# Altium schematic sheet beta.SchDoc
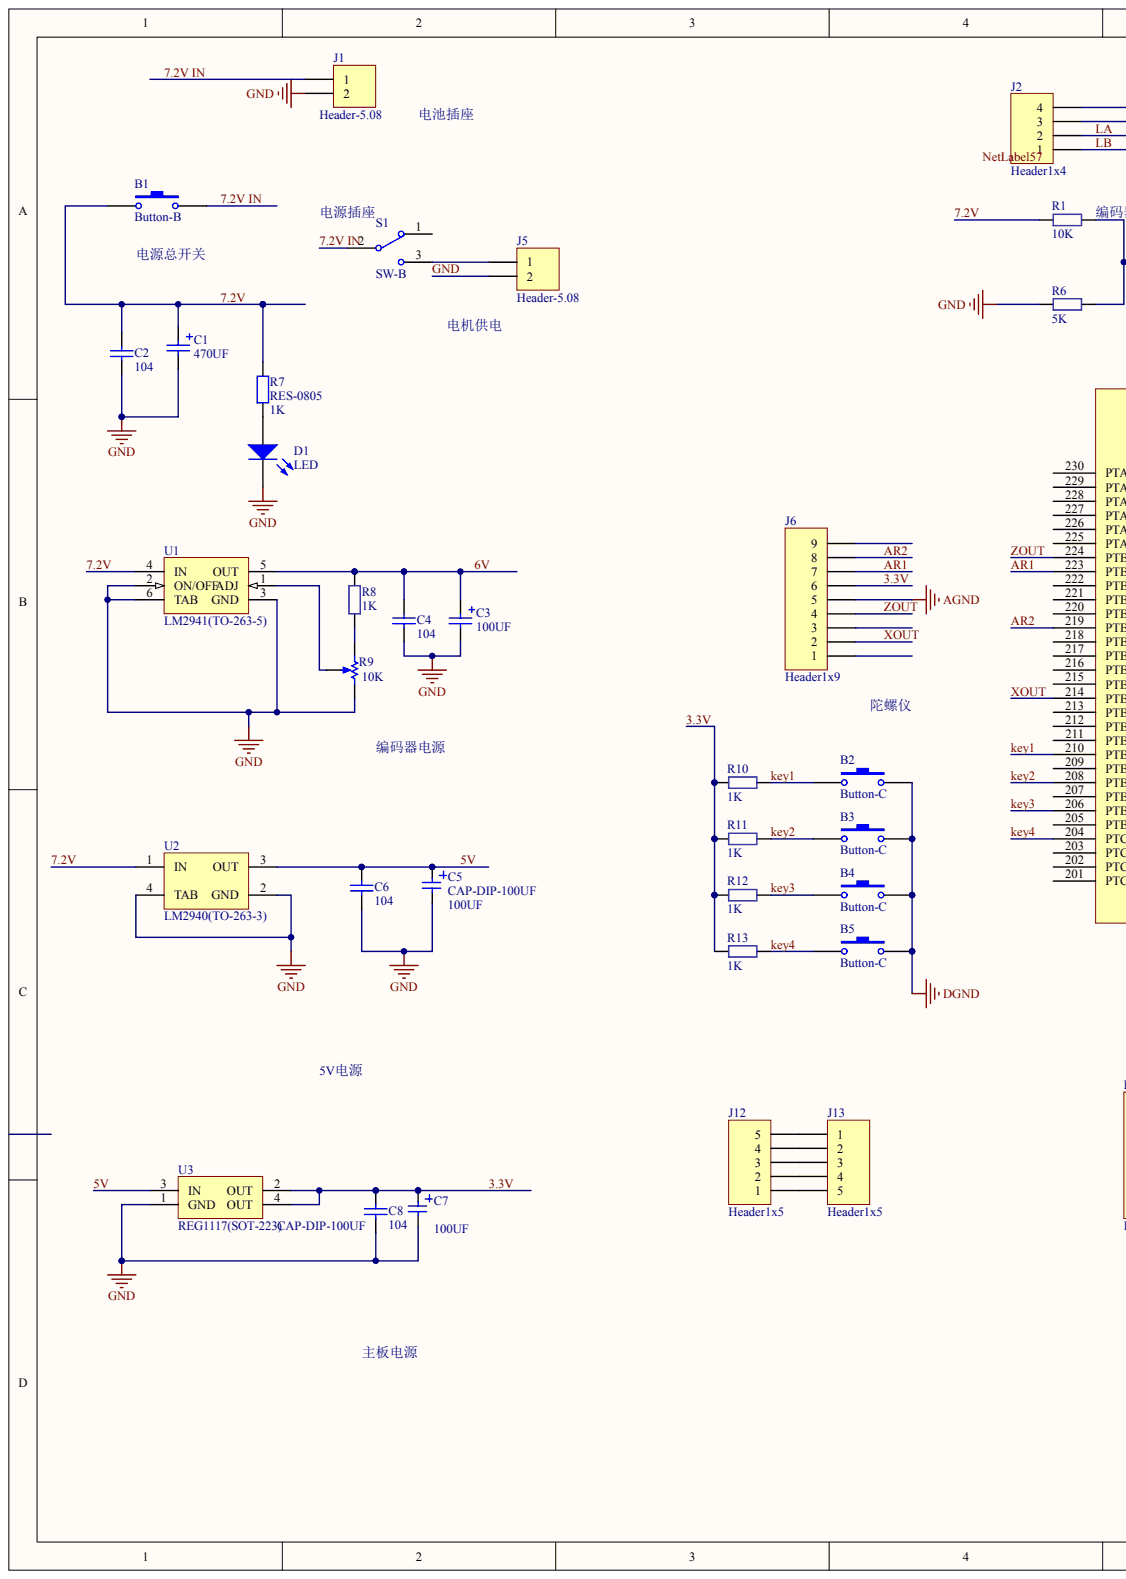
[diagram p0: beta.SchDoc, left]
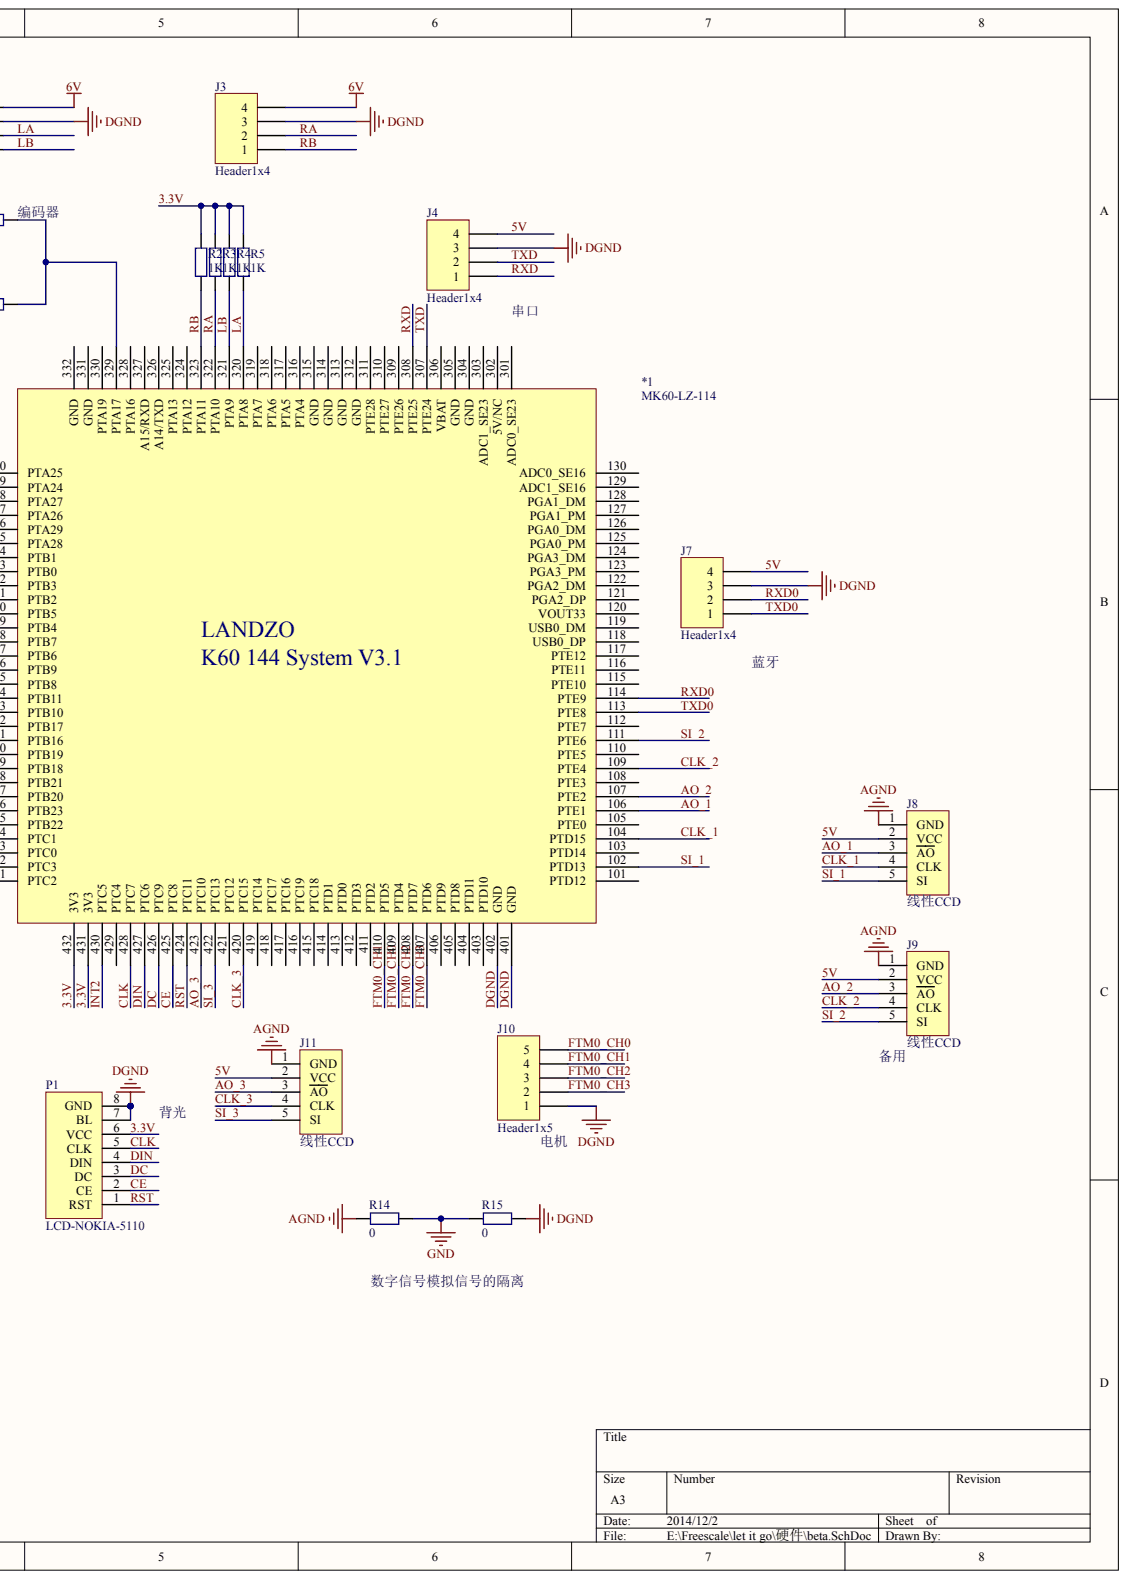
[diagram p1: beta.SchDoc, right]

## Parts
*1  MK60-LZ-114
B1  Button-B
B2  Button-C
B3  Button-C
B4  Button-C
B5  Button-C
C1  CAP-DIP-220UF  (value not drawn)
C2  CAP-0805(104)  (value not drawn)
C3  CAP-DIP-100UF
C4  CAP-0805(104)  (value not drawn)
C5  CAP-DIP-100UF
C6  CAP-0805(104)  (value not drawn)
C7  CAP-DIP-100UF
C8  CAP-0805(104)  (value not drawn)
D1  LED
J1  Header-5.08
J2  Header1x4
J3  Header1x4
J4  Header1x4
J5  Header-5.08
J6  Header1x9
J7  Header1x4
J8  线性CCD
J9  线性CCD
J10  Header1x5
J11  线性CCD
J12  Header1x5
J13  Header1x5
P1  LCD-NOKIA-5110
R1  RES-0805  (value not drawn)
R2  RES-0805  (value not drawn)
R3  RES-0805  (value not drawn)
R4  RES-0805  (value not drawn)
R5  RES-0805  (value not drawn)
R6  RES-0805  (value not drawn)
R7  RES-0805
R8  RES-0805  (value not drawn)
R9  RES-Change  (value not drawn)
R10  RES-0805  (value not drawn)
R11  RES-0805  (value not drawn)
R12  RES-0805  (value not drawn)
R13  RES-0805  (value not drawn)
R14  RES-0805  (value not drawn)
R15  RES-0805  (value not drawn)
S1  SW-B
U1  LM2941(TO-263-5)
U2  LM2940(TO-263-3)
U3  REG1117(SOT-223)

## Nets
AGND: J6.5 J8.1(GND) J9.1(GND) J11.1(GND) R14.1
3.3V: *1.431(3V3) *1.432(3V3) C7.1 C8.1 J6.6 P1.6(VCC) R2.2 R3.2 R4.2 R5.2 R10.1 R11.1 R12.1 R13.1 U3.2(OUT) U3.4(OUT)
5V: C5.1 C6.1 J4.4 J7.4 J8.2(VCC) J9.2(VCC) J11.2(VCC) U2.3(OUT) U3.3(IN)
6V: C3.1 C4.1 J2.4 J3.4 R8.2 U1.5(OUT)
7.2V: B1.1 C1.1 C2.1 R1.1 R7.2 U1.4(IN) U2.1(IN)
7.2V IN: B1.2 J1.1 S1.2
AO_1: *1.106(PTE1) J8.3(AO)
AO_2: *1.107(PTE2) J9.3(AO)
AO_3: *1.423(PTC10) J11.3(AO)
AR1: *1.223(PTB0) J6.7
AR2: *1.219(PTB4) J6.8
CE: *1.425(PTC8) P1.2(CE)
CLK: *1.428(PTC7) P1.5(CLK)
CLK_1: *1.104(PTD15) J8.4(CLK)
CLK_2: *1.109(PTE4) J9.4(CLK)
CLK_3: *1.420(PTC15) J11.4(CLK)
DC: *1.426(PTC9) P1.3(DC)
DGND: *1.401(GND) *1.402(GND) B2.2 B3.2 B4.2 B5.2 J2.3 J3.3 J4.3 J7.3 J10.1 P1.7(BL) P1.8(GND) R15.2
DIN: *1.427(PTC6) P1.4(DIN)
FTM0_CH0: *1.409(PTD4) J10.5
FTM0_CH1: *1.410(PTD5) J10.4
FTM0_CH2: *1.408(PTD7) J10.3
FTM0_CH3: *1.407(PTD6) J10.2
GND: C1.2 C2.2 C3.2 C4.2 C5.2 C6.2 C7.2 C8.2 D1.2 J1.2 J5.2 R6.1 R9.2 R14.2 R15.1 U1.2(ON/OFF) U1.3(GND) U1.6(TAB) U2.2(GND) U2.4(TAB) U3.1(GND)
INT2: *1.430(PTC5)
KEY1: *1.210(PTB19) B2.1 R10.2
KEY2: *1.208(PTB21) B3.1 R11.2
KEY3: *1.206(PTB23) B4.1 R12.2
KEY4: *1.204(PTC1) B5.1 R13.2
LA: *1.320(PTA8) J2.2 R5.1
LB: *1.321(PTA9) J2.1 R4.1
RA: *1.322(PTA10) J3.2 R3.1
RB: *1.323(PTA11) J3.1 R2.1
RST: *1.424(PTC11) P1.1(RST)
RXD: *1.308(PTE25) J4.1
RXD0: *1.114(PTE9) J7.2
SI_1: *1.102(PTD13) J8.5(SI)
SI_2: *1.111(PTE6) J9.5(SI)
SI_3: *1.422(PTC13) J11.5(SI)
TXD: *1.307(PTE24) J4.2
TXD0: *1.113(PTE8) J7.1
XOUT: *1.214(PTB11) J6.2
ZOUT: *1.224(PTB1) J6.4
Net*1_329: *1.329(PTA17) R1.2 R6.2
NetD1_1: D1.1 R7.1
NetJ5_1: J5.1 S1.3
NetJ12_1: J12.1 J13.5
NetJ12_2: J12.2 J13.4
NetJ12_3: J12.3 J13.3
NetJ12_4: J12.4 J13.2
NetJ12_5: J12.5 J13.1
NetR8_1: R8.1 R9.1
NetR9_3: R9.3 U1.1(ADJ)
N00032:
NETLABEL57:

Not connected: *1 101(PTD12) 103(PTD14) 105(PTE0) 108(PTE3) 110(PTE5) 112(PTE7) 115(PTE10) 116(PTE11) 117(PTE12) 118(USB0_DP) 119(USB0_DM) 120(VOUT33) 121(PGA2_DP) 122(PGA2_DM) 123(PGA3_PM) 124(PGA3_DM) 125(PGA0_PM) 126(PGA0_DM) 127(PGA1_PM) 128(PGA1_DM) 129(ADC1_SE16) 130(ADC0_SE16) 201(PTC2) 202(PTC3) 203(PTC0) 205(PTB22) 207(PTB20) 209(PTB18) 211(PTB16) 212(PTB17) 213(PTB10) 215(PTB8) 216(PTB9) 217(PTB6) 218(PTB7) 220(PTB5) 221(PTB2) 222(PTB3) 225(PTA28) 226(PTA29) 227(PTA26) 228(PTA27) 229(PTA24) 230(PTA25) 301(ADC0_SE23) 302(5V/NC) 303(ADC1_SE23) 304(GND) 305(GND) 306(VBAT) 309(PTE26) 310(PTE27) 311(PTE28) 312(GND) 313(GND) 314(GND) 315(GND) 316(PTA4) 317(PTA5) 318(PTA6) 319(PTA7) 324(PTA12) 325(PTA13) 326(A14/TXD) 327(A15/RXD) 328(PTA16) 330(PTA19) 331(GND) 332(GND) 403(PTD10) 404(PTD11) 405(PTD8) 406(PTD9) 411(PTD2) 412(PTD3) 413(PTD0) 414(PTD1) 415(PTC18) 416(PTC19) 417(PTC16) 418(PTC17) 419(PTC14) 421(PTC12) 429(PTC4); J6 1 3 9; S1 1

## Library data of the parts
*1 B1-B5 C1-C8 D1 J1-J13 P1 R1-R15 S1 U1-U3 = (no symbol name)
  C1: : 470UF
  C2 C4 C6 C8: : 104
  C3 C5 C7: : 100UF
  R1 R9: : 10K
  R2-R5 R7 R8 R10-R13: : 1K
  R6: : 5K
  R14 R15: : 0
